# Altium schematic sheet SWR_VHF.SchDoc
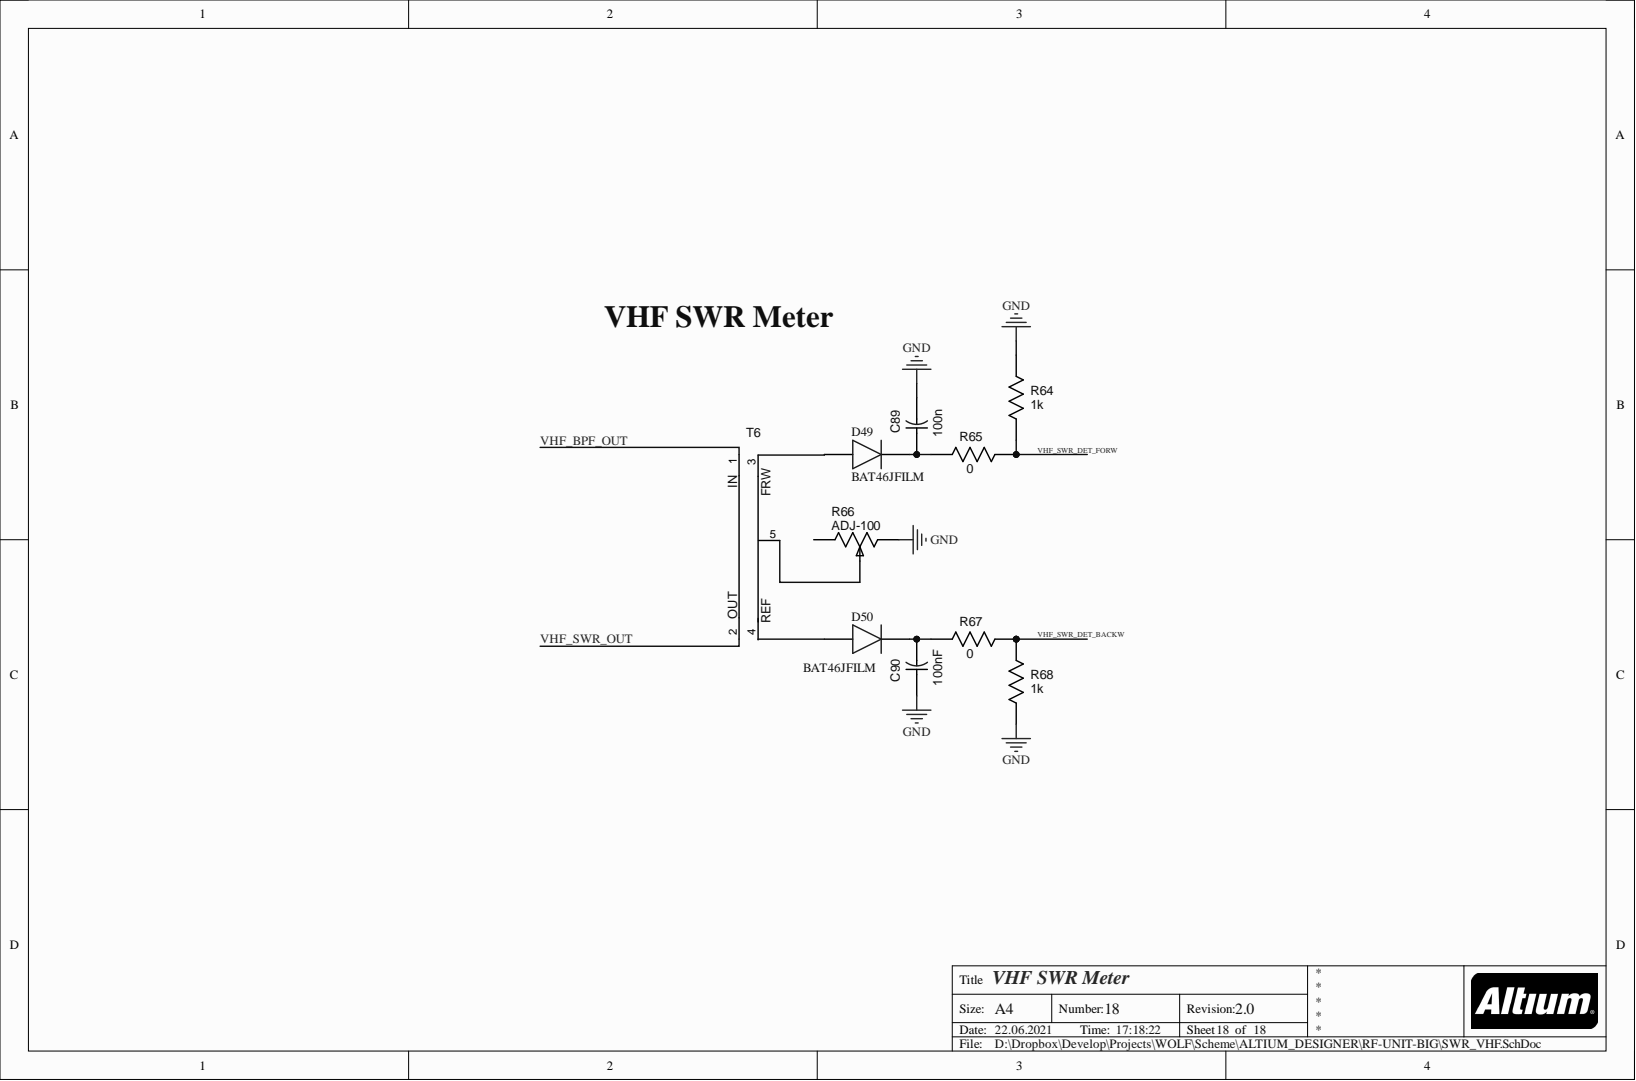
[diagram p0: SWR_VHF.SchDoc]

## Parts
C89  100n  [CAP_0805]
C90  100nF  [CAP_0805]
D49  BAT46JFILM  [SOD2513X117N]
D50  BAT46JFILM  [SOD2513X117N]
R64  1k  [RES_0805]
R65  0  [RES_0805]
R66  ADJ-100  [306J]
R67  0  [RES_0805]
R68  1k  [RES_0805]
T6  SWR_VHF  [SWR_VHF]  (value not drawn)

## Nets
GND: C89.2 C90.1 R64.2 R66.1 R68.1
VHF_BPF_OUT: T6.1(IN)
VHF_SWR_DET_BACKW: R67.2 R68.2
VHF_SWR_DET_FORW: R64.1 R65.2
VHF_SWR_OUT: T6.2(OUT)
NetC89_1: C89.1 D49.1 R65.1
NetC90_2: C90.2 D50.1 R67.1
NetD49_2: D49.2 T6.3
NetD50_2: D50.2 T6.4
NetR66_2: R66.2 T6.5

Not connected: R66 3

## Library data of the parts
C89 C90 = CAP_0805: Component Kind: Standard; Description: CAP_0805
  C89: Value: 100n
  C90: Value: 100nF
D49 D50 = BAT46JFILM: Diode; Manufacturer_Name: STMicroelectronics; Allied_Number: 70389791; Allied Price/Stock: http://www.alliedelec.com/stmicroelectronics-bat46jfilm/70389791/; Component Kind: Standard; Datasheet Link: http://www.st.com/web/en/resource/technical/document/datasheet/CD00004930.pdf; Height: 1.17mm; Manufacturer_Part_Number: BAT46JFILM; Mouser Part Number: 511-BAT46JFILM; Mouser Price/Stock: https://www.mouser.co.uk/ProductDetail/STMicroelectronics/BAT46JFILM?qs=69%252BgXnvv5lrVjJqgcSQKbQ%3D%3D; RS Part Number: 7146847P; RS Price/Stock: http://uk.rs-online.com/web/p/products/7146847P; Library Name: RF-UNIT-BIG.SchLib
R64 R65 R67 R68 = RES_0805: Component Kind: Standard; Description: RES_0805
R66 = 306J: Component Kind: Standard; Description: 306J
T6 = SWR_VHF: Component Kind: Standard; Description: SWR_VHF; Library Name: RF-UNIT-BIG.SchLib
